# Altium schematic sheet [9]-Power.SchDoc
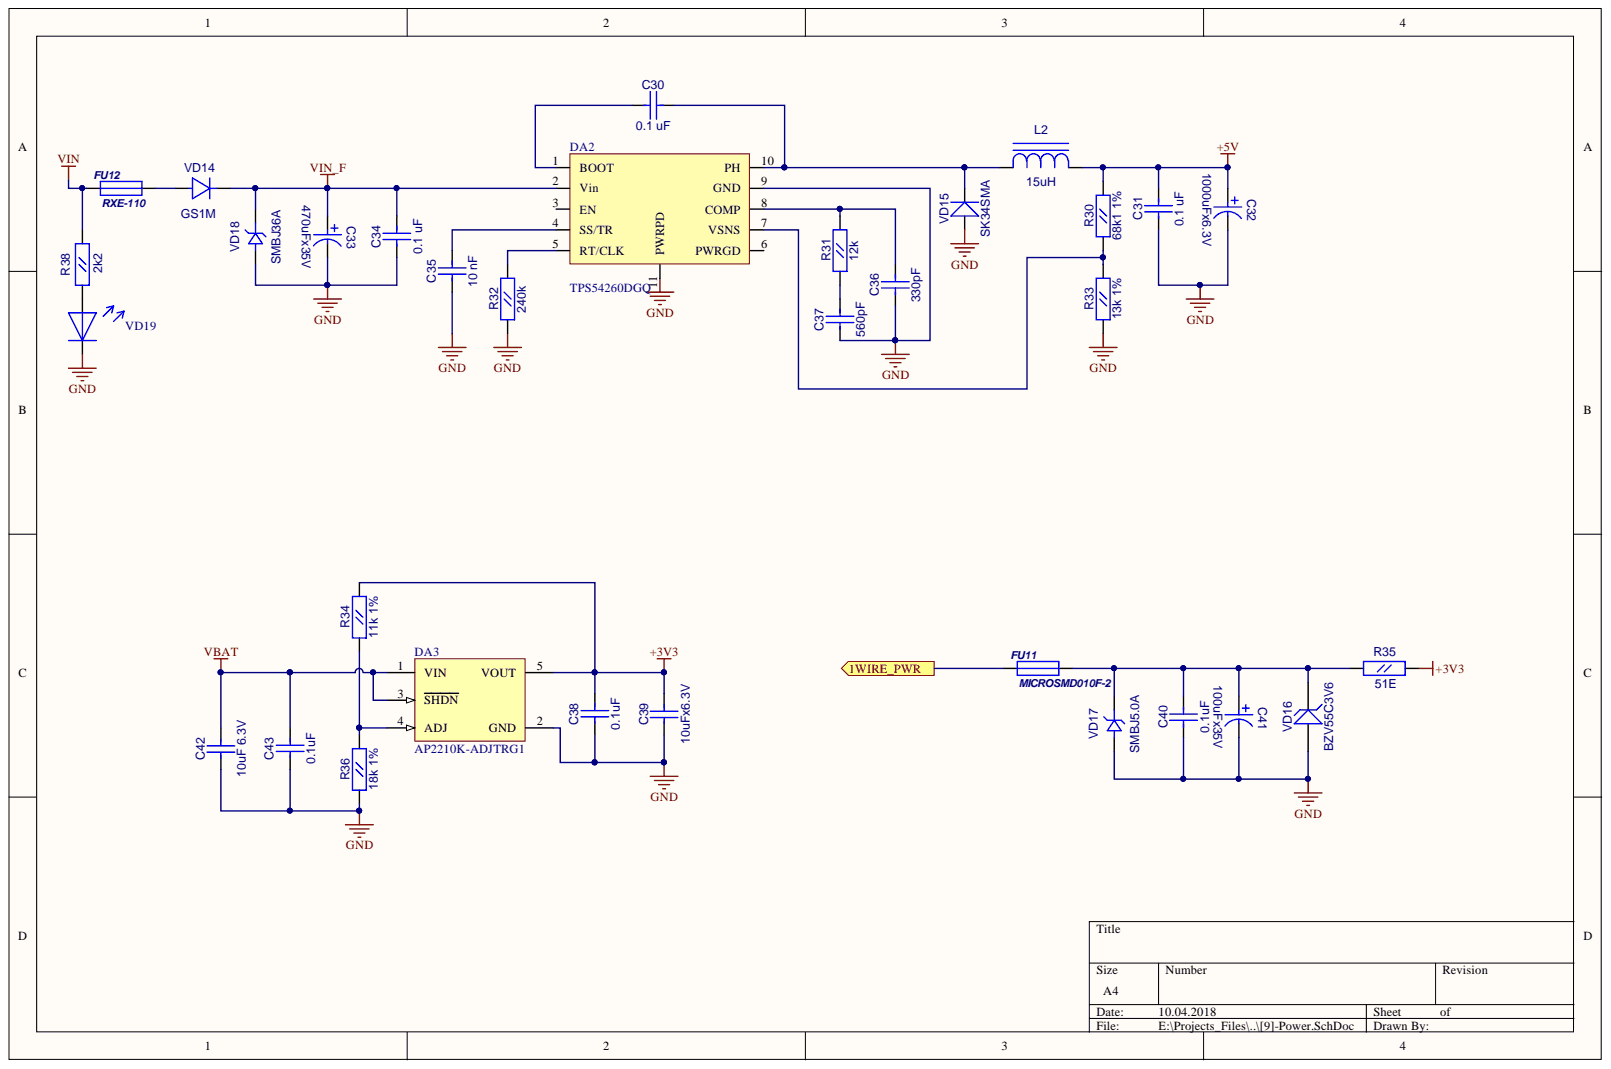
[diagram p0: [9]-Power.SchDoc]

## Parts
C30  0.1 uF  [CC0603]
C31  0.1 uF  [CC0603]
C32  1000uFx6.3V  [CC8X3.5]
C33  470uFx35V  [CC16X10_CAP0.5(1)(Primary)]
C34  0.1 uF  [CC0603]
C35  10 nF  [CC0603]
C36  330pF  [CC0603]
C37  560pF  [CC0603]
C38  0.1uF  [CC0603]
C39  10uFx6.3V  [CC0805]
C40  0.1uF  [CC0603]
C41  100uFx35V  [CC20X2.54]
C42  10uF 6.3V  [CC0805]
C43  0.1uF  [CC0603]
DA2  TPS54260DGQ  [S-PDSO-G10]
DA3  AP2210K-ADJTRG1  [SOT23-5]
FU11  MICROSMD010F-2  [RC1210]
FU12  RXE-110  [RXE-110]
L2  15uH  [SDR0805]
R30  68k1 1%  [RC0603]
R31  12k  [RC0603]
R32  240k  [RC0603]
R33  13k 1%  [RC0603]
R34  11k 1%  [RC0603]
R35  51E  [RC0603]
R36  18k 1%  [RC0603]
R38  2k2  [RC0603]
VD14  GS1M  [SMA]
VD15  SK34SMA  [SMA]
VD16  BZV55C3V6  [SOD-80]
VD17  SMBJ5.0A  [SMB]
VD18  SMBJ36A  [SMB]
VD19  LED_0805  [LED0805]  (value not drawn)

## Ports
1WIRE_PWR

## Nets
+3V3: C38.1 C39.2 DA3.5(VOUT) R34.2 R35.2
+5V: C31.1 C32.1 L2.2 R30.2
GND: C31.2 C32.2 C33.1 C34.2 C35.2 C36.2 C37.2 C38.2 C39.1 C40.1 C41.2 C42.1 C43.2 DA2.9(GND) DA2.11(PWRPD) DA3.2(GND) R32.1 R33.1 R36.1 VD15.1 VD16.1 VD17.1 VD18.1 VD19.2
VBAT: C42.2 C43.1 DA3.1(VIN) DA3.3(SHDN)
VIN: FU12.1 R38.2
VIN_F: C33.2 C34.1 DA2.2(Vin) VD14.2 VD18.2
1WIRE_PWR: FU11.1 ; port 1WIRE_PWR
NetC30_1: C30.1 DA2.10(PH) L2.1 VD15.2
NetC30_2: C30.2 DA2.1(BOOT)
NetC35_1: C35.1 DA2.4(SS/TR)
NetC36_1: C36.1 DA2.8(COMP) R31.2
NetC37_1: C37.1 R31.1
NetC40_2: C40.2 C41.1 FU11.2 R35.1 VD16.2 VD17.2
NetDA2_5: DA2.5(RT/CLK) R32.2
NetDA2_7: DA2.7(VSNS) R30.1 R33.2
NetDA3_4: DA3.4(ADJ) R34.1 R36.2
NetFU12_2: FU12.2 VD14.1
NetR38_1: R38.1 VD19.1

Not connected: DA2 3(EN) 6(PWRGD)

## Library data of the parts
C30 C31 C34-C38 C40 C43 = CC0603: Component Kind: Standard; Description: CC0603; Library Name: gprs_modem_2.SchLib
  C30 C31 C34: Value: 0.1 uF
  C35: Value: 10 nF
  C36: Value: 330pF
  C37: Value: 560pF
  C38 C40 C43: Value: 0.1uF
C32 = CAP0.35: Component Kind: Standard; Description: CAP0.35; Value: 1000uFx6.3V; Library Name: gprs_modem_2.SchLib
C33 = CAP0.5(1): Component Kind: Standard; Description: CAP0.5(1); Value: 470uFx35V; Library Name: gprs_modem_2.SchLib
C39 C42 = CC0805: Component Kind: Standard; Description: CC0805; Library Name: gprs_modem_2.SchLib
  C39: Value: 10uFx6.3V
  C42: Value: 10uF 6.3V
C41 = CAP0.25: Component Kind: Standard; Description: CAP0.25; Value: 100uFx35V; Library Name: gprs_modem_2.SchLib
DA3 = MCP1824T-ADJE/OT: 300 mA, Low Voltage, Low Quiescent Current LDO Regulator, 5-Pin SOT-223, Extended Temperature, Tape and Reel; Component Kind: Standard; ComponentLink1Description: Manufacturer Link; ComponentLink1URL: http://www.microchip.com; ComponentLink2Description: Datasheet; ComponentLink2URL: http://ww1.microchip.com/downloads/en/DeviceDoc/22070a.pdf; ComponentLink3Description: Packaging Specification; ComponentLink3URL: http://ww1.microchip.com/downloads/en/PackagingSpec/00049AW.pdf; DatasheetVersion: revA, Aug-2007; PackageDescription: 5-Lead Plastic Small Outline Transistor (DC) [SOT-223]; PackageReference: SOT-223-DC5; PackageVersion: revAW, Oct-2008; Published: 29-Jan-2009; Library Name: gprs_modem_2.SchLib; Publisher: Altium Limited
FU11 = MICROSMD: Component Kind: Standard; Description: MICROSMD; Value: MICROSMD010F-2; Library Name: gprs_modem_2.SchLib
L2 = SDR0805: Component Kind: Standard; Description: SDR0805; Value: 15uH; Library Name: gprs_modem_2.SchLib
R30-R36 R38 = RC0603: Component Kind: Standard; Description: RC0603; Library Name: gprs_modem_2.SchLib
  R30: Value: 68k1 1%
  R31: Value: 12k
  R32: Value: 240k
  R33: Value: 13k 1%
  R34: Value: 11k 1%
  R35: Value: 51E
  R36: Value: 18k 1%
  R38: Value: 2k2
VD14 = GS1M: Value: {Value}; Component Kind: Standard; Description: GS1M; Library Name: gprs_modem_2.SchLib
VD15 = SK34SMA: Schottky Rectifier; Value: {Value}; Component Kind: Standard; Library Name: gprs_modem.SchLib
VD16 = BZV55C5V1: Zener Voltage Regulator Diode; ComponentField6: Pin 2 is not connected and not drawn.; ComponentField7: Published 29-Sep-2000; OriginalName: BZX84C10LT1; Component Kind: Standard; Simulation: BZX84C10LT1; Value: BZV55C3V6; Library Name: gprs_modem_2.SchLib
VD17 VD18 = SMBJ: Component Kind: Standard; Library Name: gprs_modem_2.SchLib
  VD17: Description: SMBJ; Value: SMBJ5.0A
  VD18: Description: SMBJ36A; Value: SMBJ36A
DA2 = TPS54260DGQ: Component Kind: Standard; Library Name: gprs_modem_2.SchLib
FU12 = RXE-110: Component Kind: Standard; Description: RXE-110; Library Name: gprs_modem_2.SchLib
VD19 = LED_0805: Component Kind: Standard; Value: LED_0805; Library Name: gprs_modem_2.SchLib
